# Altium schematic sheet power.SchDoc(power)
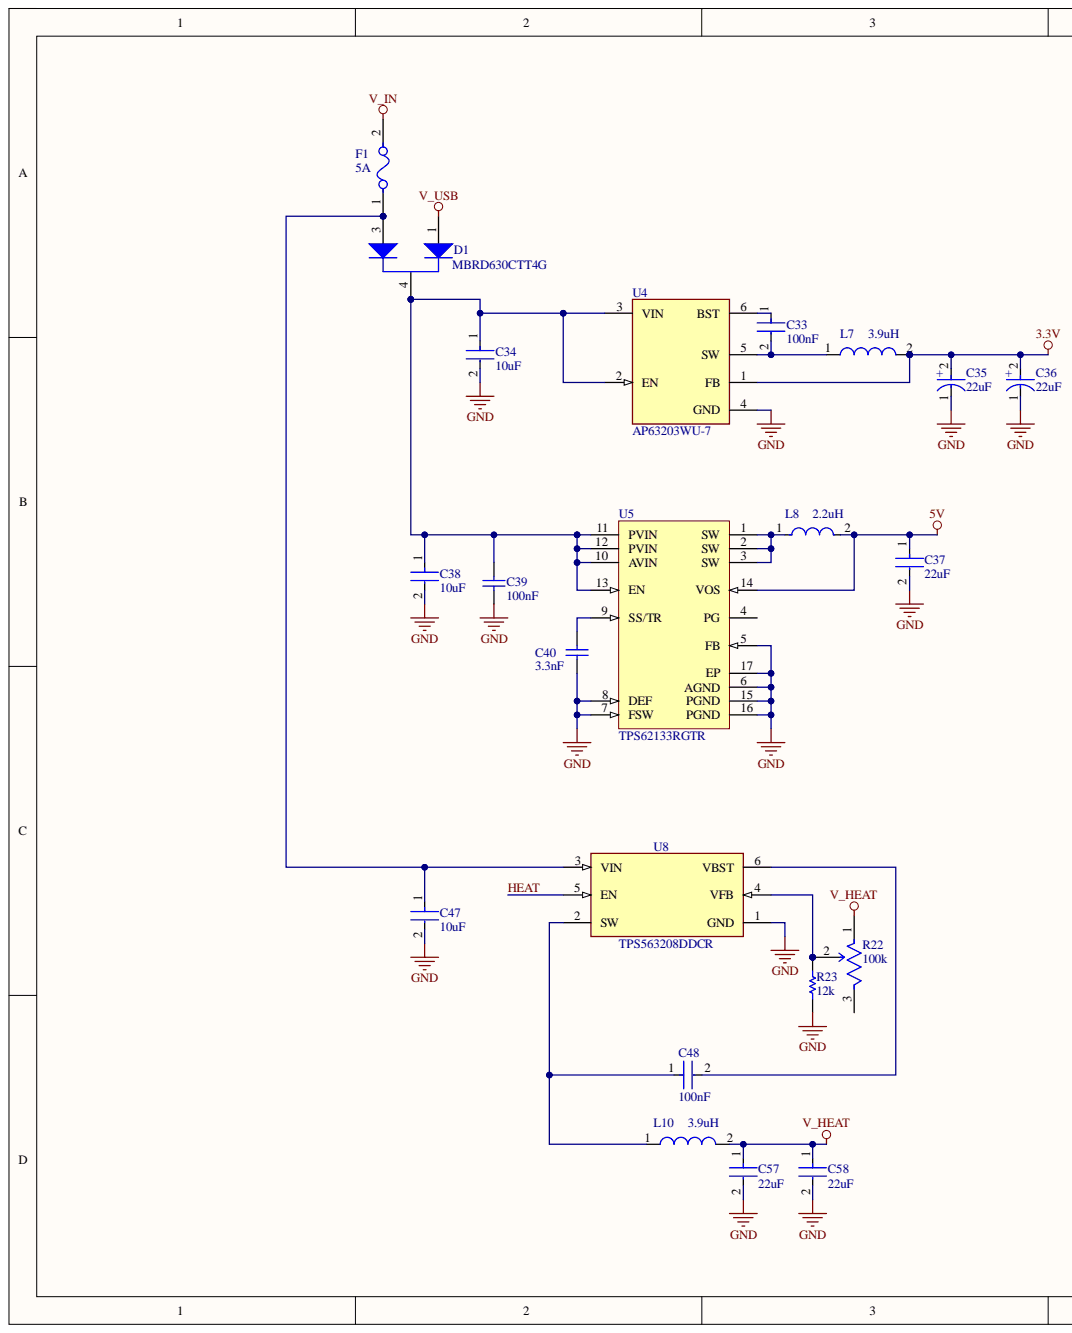
[diagram p0: power.SchDoc, left]
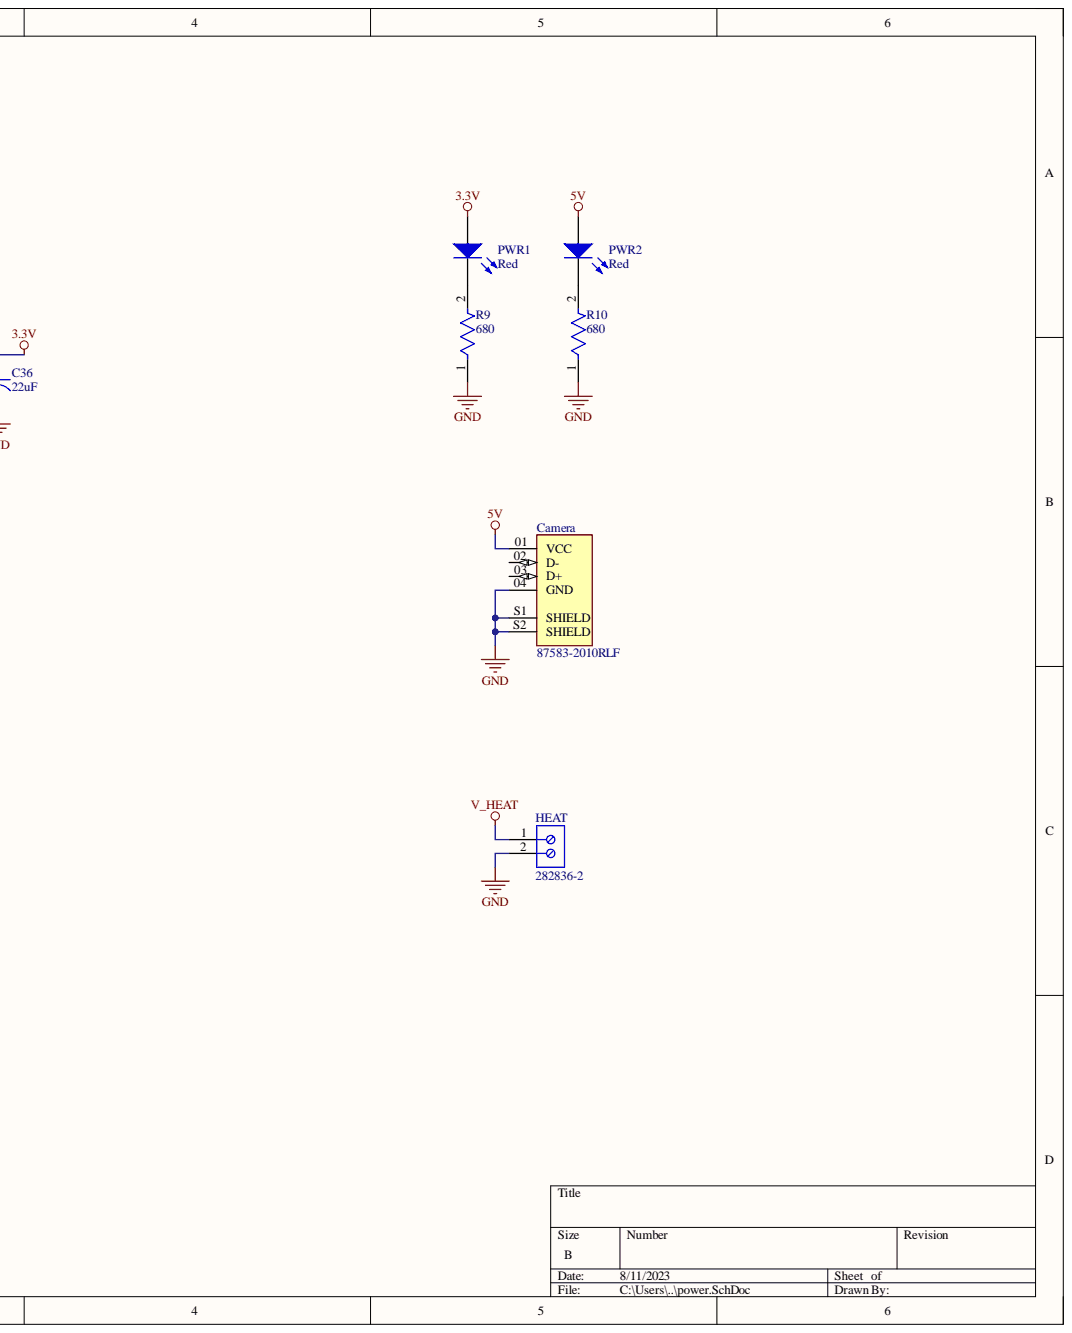
[diagram p1: power.SchDoc, right]

## Parts
C33  100nF  [FP-C0603C-CF-MFG]
C34  10uF  [FP-GRM21B-0_15-IPC_C]
C35  22uF  [FP-T491D226K025AT-MFG]
C36  22uF  [FP-T491D226K025AT-MFG]
C37  22uF  [FP-C3216-160-0_2-IPC_B]
C38  10uF  [FP-GRM21B-0_15-IPC_C]
C39  100nF  [CAPC1608X90X35NL15T15]
C40  3.3nF  [CAPC0805(2012)100_M]
C47  10uF  [FP-GRM21B-0_15-IPC_C]
C48  100nF  [FP-C0603C-CF-MFG]
C57  22uF  [FP-C3216-160-0_2-IPC_B]
C58  22uF  [FP-C3216-160-0_2-IPC_B]
Camera  87583-2010RLF  [AMPHENOL_87583-2010RLF]
D1  MBRD630CTT4G  [ONSC-DPAK-3-369C-01_V]
F1  5A  [FP-0469-MFG]
HEAT  282836-2  [TECO-282836-2_V]
L7  3.9uH  [WE-TPC-4818-M]
L8  2.2uH  [FP-SPM6530-MFG]
L10  3.9uH  [WE-TPC 8043]
PWR1  Red  [1206_A]
PWR2  Red  [1206_A]
R9  680  [FP-RC0603-0_55-MFG]
R10  680  [FP-RC0603-0_55-MFG]
R22  100k  [FP-TC33X-2-MFG]
R23  12k  [RESC1608X55X25NL10T15]
U4  AP63203WU-7  [FP-TSOT26-MFG]
U5  TPS62133RGTR  [RGT0016C_V]
U8  TPS563208DDCR  [FP-DDC0006A-MFG]

## Nets
3.3V: C35.2 C36.2 L7.2 PWR1.2 U4.1(FB)
5V: C37.1 Camera.01(VCC) L8.2 PWR2.2 U5.14(VOS)
GND: C34.2 C35.1 C36.1 C37.2 C38.2 C39.1 C40.1 C47.2 C57.2 C58.2 Camera.04(GND) Camera.S1(SHIELD) Camera.S2(SHIELD) HEAT.2 R9.1 R10.1 R23.1 U4.4(GND) U5.5(FB) U5.6(AGND) U5.7(FSW) U5.8(DEF) U5.15(PGND) U5.16(PGND) U5.17(EP) U8.1(GND)
V_HEAT: C57.1 C58.1 HEAT.1 L10.2 R22.1
V_IN: F1.2
V_USB: D1.1
HEAT: U8.5(EN)
NetC33_1: C33.1 U4.6(BST)
NetC33_2: C33.2 L7.1 U4.5(SW)
NetC34_1: C34.1 C38.1 C39.2 D1.4 U4.2(EN) U4.3(VIN) U5.10(AVIN) U5.11(PVIN) U5.12(PVIN) U5.13(EN)
NetC40_2: C40.2 U5.9(SS/TR)
NetC47_1: C47.1 D1.3 F1.1 U8.3(VIN)
NetC48_1: C48.1 L10.1 U8.2(SW)
NetC48_2: C48.2 U8.6(VBST)
NetL8_1: L8.1 U5.1(SW) U5.2(SW) U5.3(SW)
NetPWR1_1: PWR1.1 R9.2
NetPWR2_1: PWR2.1 R10.2
NetR22_2: R22.2 R23.2 U8.4(VFB)

Not connected: Camera 02(D-) 03(D+); R22 3; U5 4(PG)

## Library data of the parts
C33 C48 = CMP-2006-03061-2: CAP CER 0.1UF 50V X7R 0603; Manufacturer: KEMET; Manufacturer Part Number: C0603C104K5RACTU; Automotive Grade: Grade 1; Capacitance: 0.10 μF; Material: Ceramic; Temperature Coefficient: 30ppm/°C; Tolerance: 0.1; Value: 0.10 μF; Automotive: Yes; Category: Cap; Component Kind: Standard; ComponentLink2Description: Datasheet; ComponentLink2URL: https://api.kemet.com/component-edge/download/datasheet/C0603C100J5GACAUTO.pdf; Device Class L1: Passive Components; Device Class L2: Capacitors; Device Class L3: Ceramic Capacitors; Digikey Description: CAP CER 0.1UF 50V X7R 0603; Height: 0.87mm; Lead Free: Yes; Library Name: Altium Content Vault; Octopart: https://octopart.com/c0603c104k5ractu-kemet-139244; Package: C0603C-CF; RoHS: TRUE; Temperature: -55°C to +125°C; Temperature Characteristic: X7R; Temperature Range High: +125°C; Temperature Range Low: -55°C; Voltage Rating: 50 V
C34 C38 C47 = CMP-2007-03541-2: Chip Multilayer Ceramic Capacitors for General Purpose, 0805, 10uF, X5R, 15%, 10%, 25V; Capacitance: 10uF; Case Code (Imperial): 0805; Case Code (Metric): 2012; Case/Package: 0805; Component Kind: Standard; Depth: 1.25mm; Dielectric: X5R; Height: 1.25mm; Lead Free: Lead Free; Length: 2mm; Library Name: Altium Content Vault; Material: Ceramic; Max Operating Temperature: 85°C; Min Operating Temperature: -55°C; Mount: Surface Mount; Package Quantity: 1; Packaging: Tape and Reel; Radiation Hardening: No; Termination: SMD/SMT; Thickness: 0.053inch; Tolerance: 10%; Voltage: 25V; Voltage Rating: 25V; Voltage Rating (DC): 25V; Width: 0.049inch
C35 C36 = CMP-03017-000021-1: CAP TANT 22UF 10% 25V 2917; Dielectric Material: Tantalum; Dissipation Factor: 6%; ESR (Equivalent Series Resistance): 800mR; Leakage Current: 5.5uA; Life (Hours): 2000Hour; Polarity: Polar; Ripple Current: 433mA; Capacitance: 22uF; Case Code (Imperial): 2917; Case Code (Metric): 7343; Case/Package: 2917; Component Kind: Standard; Depth: 4.3mm; Features: General Purpose; Height: 2.8mm; Height - Seated (Max): 0.122inch; Lead Free: Lead Free; Length: 7.3mm; Library Name: Altium Content Vault; Max Operating Temperature: 125°C; Min Operating Temperature: -55°C; Mount: Surface Mount; Number of Pins: 2; Package Quantity: 1; Packaging: Tape and Reel; Radiation Hardening: No; REACH SVHC: No SVHC; Termination: SMD/SMT; Tolerance: 10%; Voltage: 25V; Voltage Rating: 25V; Voltage Rating (DC): 25V; Width: 4.3mm
C37 C57 C58 = CMP-2000-05398-2: Multilayer Ceramic Capacitors 22uF ±20% 35V X5R SMD 1206; Capacitance: 22uF; Case Code (Imperial): 1206; Case Code (Metric): 3216; Case/Package: 1206; Component Kind: Standard; Construction: Flat; Depth: 1.6mm; Dielectric: X5R; Dielectric Material: Ceramic; Height: 1.6mm; Lead Free: Lead Free; Length: 3.2mm; Library Name: Altium Content Vault; Max Operating Temperature: 85°C; Min Operating Temperature: -55°C; Mount: Surface Mount; Package Quantity: 2000; Packaging: Tape and Reel; Radiation Hardening: No; REACH SVHC: No SVHC; Resistor Type: Ceramic; RoHS Compliant: Yes; Series: C; Termination: SMD/SMT; Thickness: 1.6mm; Tolerance: 20%; Voltage: 35V; Voltage Rating: 35V; Voltage Rating (DC): 35V; Weight: 0.000571oz; Width: 0.063inch
C39 = CMP-2006-04463-1: Manufacturer: AVX Interconnect / Elco; Part Number: 06035D104KAT2A; Component Kind: Standard; Library Name: Altium Content Vault
C40 = CMP-1036-03425-1: CAP 3.3nF 50V ±10% 0805 (2012 Metric) Thickness 1mm SMD; Case-EIA: 0805; Case-Metric: 2012; Tolerance: Â±10%; Component Kind: Standard; Library Name: Altium Content Vault; Max Thickness: 1 mm; Rated Voltage: 50 V; Technology: SMT; Value: 3.3nF
Camera = 87583-2010RLF: MF: {'manufacturer': u'Amphenol ICC (FCI)'}; Availability: Unavailable; Component Kind: Standard; MP: 87583-2010RLF; Package: None; Price: None
D1 = CMP-1055-00194-1: SWITCHMODE(TM) Power Rectifier, 3-Pin DPAK, Pb-Free, Tape and Reel; Manufacturer: On Semiconductor; Configuration: Common Cathode; IFSM Max (A): 75; Component Kind: Standard; ComponentLink1Description: Manufacturer URL; ComponentLink1URL: http://www.onsemi.com/; ComponentLink2Description: Datasheet; ComponentLink2URL: http://www.onsemi.cn/pub_link/Collateral/MBRD620CT-D.PDF; ComponentLink3Description: Package Specification; ComponentLink3URL: http://www.onsemi.cn/pub_link/Collateral/369C.PDF; DatasheetVersion: Rev. 10; Library Name: Altium Content Vault; Mounting Technology: Surface Mount; PackageDescription: 3-Pin Flange Mount, Body 6.54 x 6.095 mm, Pitch 2.29 mm; PackageReference: DPAK-3-369C-01; PackageVersion: Rev. E, 02/2014; Packing: Tape and Reel; PartNumber: MBRD630CTT4G; RoHS: TRUE
F1 = CMP-08605-000100-1: FUSE BOARD MOUNT 5A 32VDC 1206; Approvals: UL; Blow Characteristic: TIME DELAY; Body Material: Ceramic; DC Cold Resistance: 35mR; Fuse Type: Board Mount (Cartridge Style Excluded); Power Dissipation: 1.08W; Voltage Rating: 32V; Case Code (Imperial): 1206; Case Code (Metric): 3216; Case/Package: 1206; Component Kind: Standard; Current Rating: 5A; Depth: 1.63mm; Fuse Current: 5A; Height: 0.9mm; Housing Material: Ceramic; Lead Free: Lead Free; Length: 3.27mm; Library Name: Altium Content Vault; Max Operating Temperature: 150°C; Min Operating Temperature: -55°C; Mount: Surface Mount; Number of Pins: 2; Package Quantity: 3000; Packaging: Tape and Reel; Radiation Hardening: No; Resistance: 35mR; Resistor Type: Ceramic; RoHS Compliant: Yes; Series: 469; Size: 0.9mm; Terminal Material: Nickel; Termination: SMD/SMT; Voltage Rating (DC): 32V; Width: 1.63mm; Working Voltage: 32V
HEAT = CMP-1684-00017-1: Female Header, Pitch 5 mm, 1 x 2 Position, Height 10 mm, Tail Length 3.5 mm, Rohs, Bulk; Manufacturer: TE Connectivity; 3D Model: 282836-2.pdf; Clamp Material - Plating: Brass-NickelPlated; Current: 13.5A; Dynamic Catalog: BuchananSeries; Featured Product: EurostyleTerminalBlockConnectors; Mating Orientation: HorizontalwithBoard; Number of Levels: 1; Other Names: 5ULV-02B  A98076; Pitch: 0.197"(5.00mm); Positions Per Level: 2; Product Training Modules: Wire-to-BoardEurostyleTerminalBlocks; Screw Thread: M3; Torque - Screw: 0.68Nm(6Lb-In); Voltage: 300V; Wire Gauge: 16-30AWG; Wire Termination: Screw-RisingCageClamp; Category: Connectors,Interconnects; Color: Green; Component Kind: Standard; Contact Material - Plating: Brass-TinPlated; Datasheet URL: http://www.te.com.cn/commerce/DocumentDelivery/DDEController?Action=showdoc&DocId=Catalog+Section%7F1308389_EUROSTYLE_TERMINAL_BLOCKS%7F0607%7Fpdf%7FEnglish%7FENG_CS_1308389_EUROSTYLE_TERMINAL_BLOCKS_0607.pdf%7F282836-2; Family: TerminalBlocks-WiretoBoard; Features: Interlocking(Side); Housing Material: Polyamide(PA66),Nylon6/6; Library Name: Altium Content Vault; Manufacturer URL: http://www.te.com; Mounting Type: ThroughHole; Package Description: Female Header, Pitch 5 mm, 1 x 2 Position, Height 10 mm, Tail Length 3.5 mm; Package Reference: THD; Packaging: Bulk; RoHS Information: 282836-2StatementofCompliance; Screw Material - Plating: Brass-NickelPlated; Series: Buchanan; Standard Package: 300
L7 = CMP-0227-00369-1: SMD Shielded Tiny Power Inductor WE-TPC, L = 3.90 µH; Inductance: 3.90 ÂµH; Component Kind: Standard; ComponentLink1Description: Manufacturer Link; ComponentLink1URL: http://www.we-online.com; ComponentLink2Description: Datasheet; ComponentLink2URL: http://www.we-online.de/katalog/media/pdf/7440420039.pdf; DatasheetVersion: ver 6, Aug-2010; Library Name: Altium Content Vault; PackageDescription: SMD Inductor, 2-Leads, Body 4.8 x 4.8 mm; PackageReference: WE-TPC-4818-M; PackageVersion: 2010
L8 = CMP-08253-000021-1: FIXED IND 2.2UH 8.2A 19 MOHM SMD; Manufacturer: TDK; Manufacturer Part Number: SPM6530T-2R2M; Core Material: Metal; Saturation Current: 8.4A; Series Resistance: 19.1mΩ; Shielding: true; Standoff Height: 0.15mm; Test Frequency: 100kHz; Tolerance: 20%; Automotive: No; Category: Ind; Component Kind: Standard; ComponentLink2Description: Datasheet; ComponentLink2URL: https://product.tdk.com/info/en/catalog/datasheets/inductor_commercial_power_spm6530_en.pdf; Composition: Wirewound; Device Class L1: Passive Components; Device Class L2: Inductors; Device Class L3: Fixed Inductors; Digikey Description: FIXED IND 2.2UH 8.2A 19 MOHM SMD; Height: 3mm; Inductance: 2.2uH; Lead Free: Yes; Library Name: Altium Content Vault; Mouser Description: Fixed Inductors 2.2uH 0.0173ohms 7.1x6.5x3mm; Octopart: https://octopart.com/spm6530t-2r2m-tdk-18903909; Package: SPM6530; Rms Current: 8.2A; RoHS: TRUE; Temperature: -40°C to +125°C; Temperature Range High: +125°C; Temperature Range Low: -40°C; Value: 2.2uH
L10 = CMP-1479-00022-1: SMD Shielded Tiny Power Inductor WE-TPC, L=23.90 µH; Manufacturer: Wuerth Elektronik; PartNumber: 744071039; Inductance: 3.90 ÂµH; Component Kind: Standard; ComponentLink1Description: Manufacturer URL; ComponentLink1URL: http://www.we-online.com; ComponentLink2Description: Datasheet; ComponentLink2URL: http://www.we-online.de/katalog/media/pdf/744071039.pdf; Library Name: Altium Content Vault; PackageReference: WE-TPC 8043
PWR1 PWR2 = CMP-1426-00017-1: SMD mono-color Chip LED, WL-SMCW, Super Red; Manufacturer: Wuerth Elektronik; PartNumber: 150120SS75000; Color: Super Red; Component Kind: Standard; ComponentLink1Description: Manufacturer URL; ComponentLink1URL: http://www.we-online.com; ComponentLink2Description: Datasheet; ComponentLink2URL: http://katalog.we-online.com/led/datasheet/150120SS75000.pdf; Library Name: Altium Content Vault; PackageReference: 1206_A
R9 R10 = CMP-03412-043893-1: RES 680 OHM 1% 1/10W 0603; Power Rating: 100mW; Resistance: 680R; Temperature Coefficient: 100ppm/°C; Voltage Rating: 50V; Case Code (Imperial): 0603; Case Code (Metric): 1608; Case/Package: 0603; Component Kind: Standard; Contact Plating: Tin; Height: 0.45mm; Length: 1.6mm; Library Name: Altium Content Vault; Max Operating Temperature: 155°C; Min Operating Temperature: -55°C; Packaging: Tape and Reel; Radiation Hardening: No; Resistor Type: Thick Film; RoHS Compliant: Yes; Series: RC; Termination: SMD/SMT; Tolerance: 1%; Width: 0.8mm
R22 = CMP-07234-000040-1: TRIMMER 100K OHM 0.1W J LEAD TOP; Max Power Dissipation: 100mW; Number of Turns: 1; Resistance: 100kR; Resistance Tolerance: 25%; Temperature Coefficient: 250ppm/°C; Voltage Rating (DC): 50V; Color: Silver; Component Kind: Standard; Depth: 3.8mm; Height: 0.047inch; Length: 3mm; Library Name: Altium Content Vault; Max Operating Temperature: 100°C; Military Standard: Not; Min Operating Temperature: -40°C; Mount: Surface Mount; Package Quantity: 2500; Packaging: Tape and Reel; Power Rating: 100mW; Radiation Hardening: No; REACH SVHC: No SVHC; Resistor Type: Carbon; RoHS Compliant: Yes; Series: TC33; Termination: Foot Print; Tolerance: 25%; Weight: 29.4mg; Width: 0.118inch
R23 = CMP-1659-00047-1: Chip Resistor, 12 KOhm, +/- 1%, 100 mW, -55 to 155 degC, 0603 (1608 Metric), RoHS, Tape and Reel; Case\Package: 0603; Power Rating: 100 mW; Resistance: 220 kohm; Voltage Rating: 50 V; Case Code (Imperial): 0603; Case Code (Metric): 1608; Component Kind: Standard; ComponentLink1Description: Manufacturer URL; ComponentLink1URL: http://www.yageo.com/; ComponentLink2Description: Datasheet; ComponentLink2URL: http://www.yageo.com/documents/recent/PYu-RC_Group_51_RoHS_L_04.pdf; DatasheetVersion: Rev. 4, 02/2016; Height: 0.45 mm; Length: 1.6 mm; Library Name: Altium Content Vault; Max Operating Temperature: 155 degC; Min Operating Temperature: -55 degC; Mount: Surface Mount; Package Quantity: 5000; PackageDescription: 2-Pin Surface Mount Device, Body 1.6 x 0.8 mm; PackageReference: 0603; Packaging: Tape and Reel; Pins: 2; REACH SVHC: No SVHC; RoHSCompliant: true; Tolerance: 1%; Voltage Rating (DC): 50 V; Width: 0.8 mm
U4 = CMP-12757-000006-1: IC REG BUCK 3.3V 2A TSOT26; Manufacturer: Diodes Inc.; Max Junction Temp: +160°C; Max Supply Voltage: 32V; Min Supply Voltage: 3.8V; Nominal Supply Current: 22uA; Number Of Outputs: 1; Output Type: Fixed; Standoff Height: 0.01mm; Switching Frequency: 1.1MHz; Ambient Temperature Range High: +85°C; Ambient Temperature Range Low: -40°C; Automotive: No; Category: IC; Component Kind: Standard; ComponentLink2Description: Datasheet; ComponentLink2URL: https://www.diodes.com/assets/Datasheets/AP63200-AP63201-AP63203-AP63205.pdf; Device Class L1: Integrated Circuits (ICs); Device Class L2: Power Management ICs; Device Class L3: Voltage Regulators - Switching; Digikey Description: IC REG BUCK 3.3V 2A TSOT26; Footprint Url: https://www.diodes.com/assets/Package-Files/TSOT26.pdf; Height: 1mm; Lead Free: Yes; Library Name: Altium Content Vault; Manufacturer Part Number: AP63203WU-7; Mouser Description: AP63203 Series 3.3 V 2 A SMT Fixed Low IQ Synchronous Buck - TSOT-26; Octopart: https://octopart.com/ap63203wu-7-diodes+inc.-98099550; Output Current: 2A; Output Voltage: 3.3V; Package: TSOT26; RoHS: TRUE; Switching Topology: Buck; Temperature: to +160°C; Temperature Range High: +160°C
U5 = CMP-0323-00146-3: Buck Step Down Regulator with 3 to 17 V Input and 5 V Output, -40 to 85 degC, 16-Pin QFN (RGT), Green (RoHS & no Sb/Br); Manufacturer: Texas Instruments; Duty Cycle(Max)(%): 100; Iout(Max)(A): 3; Iq(Typ)(mA): 0.017; Preset Vout(V): 5; Rating: Catalog; Regulated Outputs(#): 1; SubFamily: Step-Down Regulator; Switch Current Limit(Typ)(A): 4.2; Synchronous Rectification: TRUE; Vin(Max)(V): 17; Code_JEDEC: MO-220; Component Kind: Standard; ComponentLink1Description: Datasheet; ComponentLink1URL: http://www.ti.com/general/docs/lit/getliterature.tsp?genericPartNumber=TPS62133&fileType=pdf; ComponentLink2Description: Package Specification; ComponentLink2URL: http://www.ti.com/litv/pdf/mpqf119g; ComponentLink3Description: URL Link1; ComponentLink3URL: Samples URL:http://www.ti.com/product/tps62133#samples; DatasheetVersion: SLVSAG7; Eco-Plan: Green (RoHS & no Sb/Br); Library Name: Altium Content Vault; Mounting Technology: Surface Mount; PackageDescription: 16-Pin Plastic Quad Flat No-Lead, 3 x 3 mm Body, 0.5 mm Pitch, 1.7 x 1.7 mm Exposed Pad; PackageReference: RGT0016C; PackageVersion: revH, Oct-2011; PartNumber: TPS62133RGTR; Topology: Buck; Vin(Min)(V): 3; Vout(Max)(V): 5; Vout(Min)(V): 5
U8 = CMP-04918-000356-1: IC REG BUCK ADJ 3A TSOT23-6; Max Input Voltage: 17V; Max Output Voltage: 7V; Min Input Voltage: 4.5V; Min Output Voltage: 768mV; Number of Outputs: 1; Output Configuration: Positive; Output Type: Adjustable; Quiescent Current: 400uA; Switching Frequency: 580kHz; Synchronous Rectifier: Yes; Case/Package: SOT-23-6; Component Kind: Standard; Lead Free: Lead Free; Library Name: Altium Content Vault; Max Operating Temperature: 125°C; Min Operating Temperature: -40°C; Mount: Surface Mount; Output Current: 3A; Packaging: Tape and Reel; RoHS Compliant: Yes
